# Altium schematic sheet Main.SchDoc
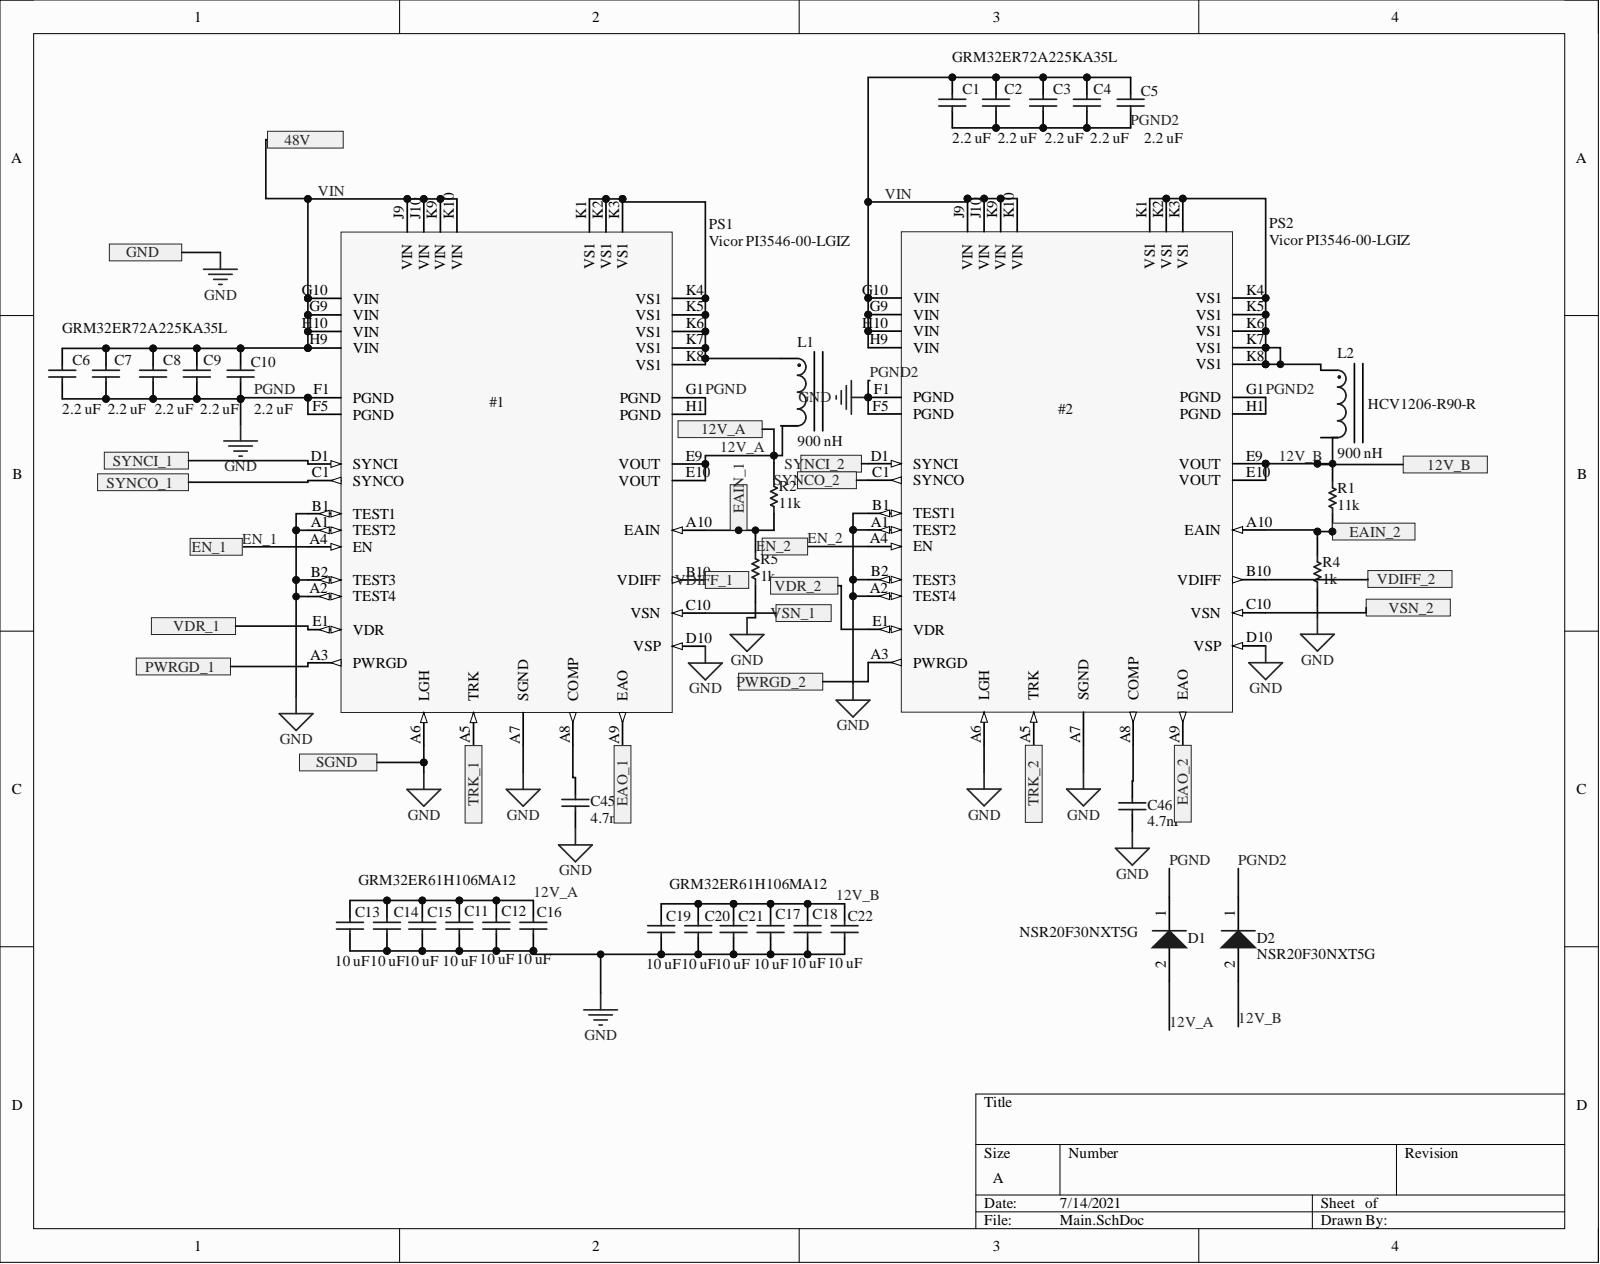
[diagram p0: Main.SchDoc]

## Parts
C1  2.2 uF  Manufacturer Part Number: GRM32ER72A225KA35L  [PCB-78b35nuemavp9s8c4ky0-1]
C2  2.2 uF  Manufacturer Part Number: GRM32ER72A225KA35L  [PCB-78b35nuemavp9s8c4ky0-1]
C3  2.2 uF  Manufacturer Part Number: GRM32ER72A225KA35L  [PCB-78b35nuemavp9s8c4ky0-1]
C4  2.2 uF  Manufacturer Part Number: GRM32ER72A225KA35L  [PCB-78b35nuemavp9s8c4ky0-1]
C5  2.2 uF  Manufacturer Part Number: GRM32ER72A225KA35L  [PCB-78b35nuemavp9s8c4ky0-1]
C6  2.2 uF  Manufacturer Part Number: GRM32ER72A225KA35L  [PCB-78b35nuemavp9s8c4ky0-1]
C7  2.2 uF  Manufacturer Part Number: GRM32ER72A225KA35L  [PCB-78b35nuemavp9s8c4ky0-1]
C8  2.2 uF  Manufacturer Part Number: GRM32ER72A225KA35L  [PCB-78b35nuemavp9s8c4ky0-1]
C9  2.2 uF  Manufacturer Part Number: GRM32ER72A225KA35L  [PCB-78b35nuemavp9s8c4ky0-1]
C10  2.2 uF  Manufacturer Part Number: GRM32ER72A225KA35L  [PCB-78b35nuemavp9s8c4ky0-1]
C11  10 uF  Part: GRM32ER61H106MA12  [PCB-zgw9hhbvba4syb3n46j4-1]
C12  10 uF  Part: GRM32ER61H106MA12  [PCB-zgw9hhbvba4syb3n46j4-1]
C13  10 uF  Part: GRM32ER61H106MA12  [PCB-zgw9hhbvba4syb3n46j4-1]
C14  10 uF  Part: GRM32ER61H106MA12  [PCB-zgw9hhbvba4syb3n46j4-1]
C15  10 uF  Part: GRM32ER61H106MA12  [PCB-zgw9hhbvba4syb3n46j4-1]
C16  10 uF  Part: GRM32ER61H106MA12  [PCB-zgw9hhbvba4syb3n46j4-1]
C17  10 uF  Part: GRM32ER61H106MA12  [PCB-zgw9hhbvba4syb3n46j4-1]
C18  10 uF  Part: GRM32ER61H106MA12  [PCB-zgw9hhbvba4syb3n46j4-1]
C19  10 uF  Part: GRM32ER61H106MA12  [PCB-zgw9hhbvba4syb3n46j4-1]
C20  10 uF  Part: GRM32ER61H106MA12  [PCB-zgw9hhbvba4syb3n46j4-1]
C21  10 uF  Part: GRM32ER61H106MA12  [PCB-zgw9hhbvba4syb3n46j4-1]
C22  10 uF  Part: GRM32ER61H106MA12  [PCB-zgw9hhbvba4syb3n46j4-1]
C45  4.7nF  [CAPC3216X88X50NL20T25]
C46  4.7nF  [CAPC3216X88X50NL20T25]
D1  NSR20F30NXT5G  [ONSC-DSN-2-512AB-01_V]
D2  NSR20F30NXT5G  [ONSC-DSN-2-512AB-01_V]
L1  900 nH  [PCB-fhixwsdd3pz4h3gl9v7v-1]
L2  900 nH  Manufacturer Part Number: HCV1206-R90-R  [PCB-fhixwsdd3pz4h3gl9v7v-1]
PS1  Vicor PI3546-00-LGIZ  [PCB-bj3e87ltw16gwkv68c8j-1]
PS2  Vicor PI3546-00-LGIZ  Manufacturer Part Number: PI3546-00-LGIZ  [PCB-bj3e87ltw16gwkv68c8j-1]
R1  11k  [RESC3225X60X45NL20T20]
R2  11k  [RESC3225X60X45NL20T20]
R4  1k  [RESC3225X60X45NL20T20]
R5  1k  [RESC3225X60X45NL20T20]

## Ports
12V_A, 12V_B, 48V, EAIN_1, EAIN_2, EAO_1, EAO_2, EN_1, EN_2, GND, PWRGD_1, PWRGD_2, SGND, SYNCI_1, SYNCI_2, SYNCO_1, SYNCO_2, TRK_1, TRK_2, VDIFF_1, VDIFF_2, VDR_1, VDR_2, VSN_1, VSN_2

## Nets
12V_A: C11.2 C12.2 C13.2 C14.2 C15.2 C16.2 D1.2 L1.2 PS1.E9(VOUT) PS1.E10(VOUT) R2.2 ; port 12V_A
12V_B: C17.2 C18.2 C19.2 C20.2 C21.2 C22.2 D2.2 L2.2 PS2.E9(VOUT) PS2.E10(VOUT) R1.2 ; port 12V_B
EAIN_1: PS1.A10(EAIN) R2.1 R5.2 ; port EAIN_1
EAIN_2: PS2.A10(EAIN) R1.1 R4.2 ; port EAIN_2
EAO_1: PS1.A9(EAO) ; port EAO_1
EAO_2: PS2.A9(EAO) ; port EAO_2
EN_1: PS1.A4(EN) ; port EN_1
EN_2: PS2.A4(EN) ; port EN_2
PGND: C1.1 C2.1 C3.2 C4.1 C5.1 C6.1 C7.1 C8.2 C9.1 C10.1 C11.1 C12.1 C13.1 C14.1 C15.1 C16.1 C17.1 C18.1 C19.1 C20.1 C21.1 C22.1 C45.1 C46.1 D1.1 D2.1 PS1.A1(TEST2) PS1.A2(TEST4) PS1.A6(LGH) PS1.A7(SGND) PS1.B1(TEST1) PS1.B2(TEST3) PS1.D10(VSP) PS1.F1(PGND) PS1.F5(PGND) PS1.G1(PGND) PS1.H1(PGND) PS2.A1(TEST2) PS2.A2(TEST4) PS2.A6(LGH) PS2.A7(SGND) PS2.B1(TEST1) PS2.B2(TEST3) PS2.D10(VSP) PS2.F1(PGND) PS2.F5(PGND) PS2.G1(PGND) PS2.H1(PGND) R4.1 R5.1 ; port GND, port SGND
PWRGD_1: PS1.A3(PWRGD) ; port PWRGD_1
PWRGD_2: PS2.A3(PWRGD) ; port PWRGD_2
SYNCI_1: PS1.D1(SYNCI) ; port SYNCI_1
SYNCI_2: PS2.D1(SYNCI) ; port SYNCI_2
SYNCO_1: PS1.C1(SYNCO) ; port SYNCO_1
SYNCO_2: PS2.C1(SYNCO) ; port SYNCO_2
TRK_1: PS1.A5(TRK) ; port TRK_1
TRK_2: PS2.A5(TRK) ; port TRK_2
VDIFF_1: PS1.B10(VDIFF) ; port VDIFF_1
VDIFF_2: PS2.B10(VDIFF) ; port VDIFF_2
VDR_1: PS1.E1(VDR) ; port VDR_1
VDR_2: PS2.E1(VDR) ; port VDR_2
VIN: C1.2 C2.2 C3.1 C4.2 C5.2 C6.2 C7.2 C8.1 C9.2 C10.2 PS1.G9(VIN) PS1.G10(VIN) PS1.H9(VIN) PS1.H10(VIN) PS1.J9(VIN) PS1.J10(VIN) PS1.K9(VIN) PS1.K10(VIN) PS2.G9(VIN) PS2.G10(VIN) PS2.H9(VIN) PS2.H10(VIN) PS2.J9(VIN) PS2.J10(VIN) PS2.K9(VIN) PS2.K10(VIN) ; port 48V
VSN_1: PS1.C10(VSN) ; port VSN_1
VSN_2: PS2.C10(VSN) ; port VSN_2
NetC45_2: C45.2 PS1.A8(COMP)
NetC46_2: C46.2 PS2.A8(COMP)
NetL1_1: L1.1 PS1.K1(VS1) PS1.K2(VS1) PS1.K3(VS1) PS1.K4(VS1) PS1.K5(VS1) PS1.K6(VS1) PS1.K7(VS1) PS1.K8(VS1)
NetL2_1: L2.1 PS2.K1(VS1) PS2.K2(VS1) PS2.K3(VS1) PS2.K4(VS1) PS2.K5(VS1) PS2.K6(VS1) PS2.K7(VS1) PS2.K8(VS1)

## Library data of the parts
C1-C10 = CMP-39fff0f61d13e638-1: 1210 2.2 uF 100 V ±10 % Tolerance X7R Surface Mount Multilayer Ceramic Capacitor; Capacitance: 2.20 µF; Capacitance Tolerance: ±10%; Lead-Free Status: Lead Free; Voltage Rating (DC): 100 V; Case/Package: 1210; Component Kind: Standard; Dielectric Characteristic: X7R; Library Name: CommunityVault; Mounting Style: Surface Mount; Number of Pins: 2; Octopart URL: http://octopart.com/grm32er72a225ka35l-murata-197002; OctopartID: 39fff0f61d13e638; Packaging: Cut Tape (CT); REACH SVHC Compliance: No SVHC; RoHS: Compliant; Size-Height: 2.50 mm; Size-Length: 3.20 mm; Size-Thickness: 2.50 mm; Size-Width: 2.50 mm
C11-C22 = CMP-822b4a75de635dec-1: 10µF ±10% 50V X7R Ceramic Capacitor -55°C ~ 125°C Surface Mount, MLCC 1210 (3225 Metric) 0.126" L x 0.098" W (3.20mm x 2.50mm); Manufacturer: Taiyo Yuden; Manufacturer Part Number: UMK325AB7106KM-T; Vendor Part Number: 587-3167-1-ND; Vendor: Digikey; Applications: General Purpose; Capacitance: 10µF; Category: Capacitors; Component Kind: Standard; Cost: 0.94; Family: Ceramic Capacitors; HelpURL: http://www.yuden.co.jp/productdata/catalog/en/mlcc_all_e.pdf; Library Name: CommunityVault; Mounting Type: Surface Mount, MLCC; Octopart URL: http://octopart.com/umk325ab7106km-t-taiyo+yuden-22313262; OctopartID: 822b4a75de635dec; Operating Temperature: -55°C ~ 125°C; Package / Case: 1210 (3225 Metric); Size / Dimension: 0.126" L x 0.098" W (3.20mm x 2.50mm); Temperature Coefficient: X7R; Thickness (Max): 0.110" (2.80mm); Tolerance: ±10%; Voltage - Rated: 50V
C45 C46 = CMP-8261912-2: Multilayer Ceramic Capacitors MLCC - SMD/SMT 50volts 4700pF 10% X7R; Manufacturer Part Number: C1206C472K5RAC; Capacitance: 4.7e-09 F; Lead-Free Status: R; Voltage Rating (DC): 50.0 V; Capacitance Tolerance: ±10%; Case/Package: 1206; Component Kind: Standard; Dielectric Characteristic: X7R; Dielectric Material: Ceramic; Library Name: CommunityVault; Mounting Style: Surface Mount; Number of Pins: 2; Octopart Url: https://octopart.com/c1206c472k5rac-kemet-2757609; OctopartID: a9a660869f297661; RoHS: Compliant
D1 D2 = CMP-816723-2: Schottky Barrier Diode, 2-Pin DSN, Pb-Free, Tape and Reel; Lead-Free Status: Lead Free; Case/Package: 0603; Component Kind: Standard; Library Name: CommunityVault; Lifecycle Status: Active; Manufacturer Part Number: NSR20F30NXT5G; Number of Pins: 2; Octopart Url: https://octopart.com/nsr20f30nxt5g-on+semiconductor-15755301; OctopartID: 345bfda17918eb9c; Packaging: Cut Tape (CT); REACH SVHC Compliance: No SVHC; RoHS: Compliant
L1 = CMP-a24368b280507d84-2: Inductor Power Wirewound 900nH 10% 100KHz Ferrite 14A 4.6mOhm DCR T/R; Manufacturer Part Number: HCV1206-R90-R; Lead-Free Status: Lead Free; Component Kind: Standard; Library Name: CommunityVault; Octopart URL: https://octopart.com/hcv1206-r90-r-eaton-66783093; OctopartID: a24368b280507d84; RoHS: Compliant
L2 = CMP-a24368b280507d84-2: Inductor Power Wirewound 900nH 10% 100KHz Ferrite 14A 4.6mOhm DCR T/R; Lead-Free Status: Lead Free; Component Kind: Standard; Library Name: CommunityVault; Octopart URL: https://octopart.com/hcv1206-r90-r-eaton-66783093; OctopartID: a24368b280507d84; Part Number: HCV1206-R90-R; RoHS: Compliant
PS1 PS2 = CMP-c6710c153a4e19b6-3: PI35xx Series 5 V 10 A 50 W SMT Cool-Power ZVS Buck Regulator - LGA-123; Lead-Free Status: Lead Free; Component Kind: Standard; Library Name: CommunityVault; Octopart URL: https://octopart.com/pi3546-00-lgiz-vicor-57596249; OctopartID: c6710c153a4e19b6; RoHS: Compliant
  PS1: Manufacturer Part Number: PI3546-00-LGIZ
R1 R2 R4 R5 = CMP-1861343-2: Thick Film Resistors - SMD 3/4watt 1Kohms 5%; Manufacturer Part Number: CRCW12101K00JNEAHP; Lead-Free Status: Lead Free; Power Rating: 0.5 W; Resistance: 1000.0 Ω; Case/Package: 1210; Component Kind: Standard; Library Name: CommunityVault; Octopart Url: https://octopart.com/crcw12101k00jneahp-vishay+dale-44516511; OctopartID: 41618acc20989ea6; RoHS: Compliant
